# Altium schematic sheet FPGA.SchDoc(FPGA)
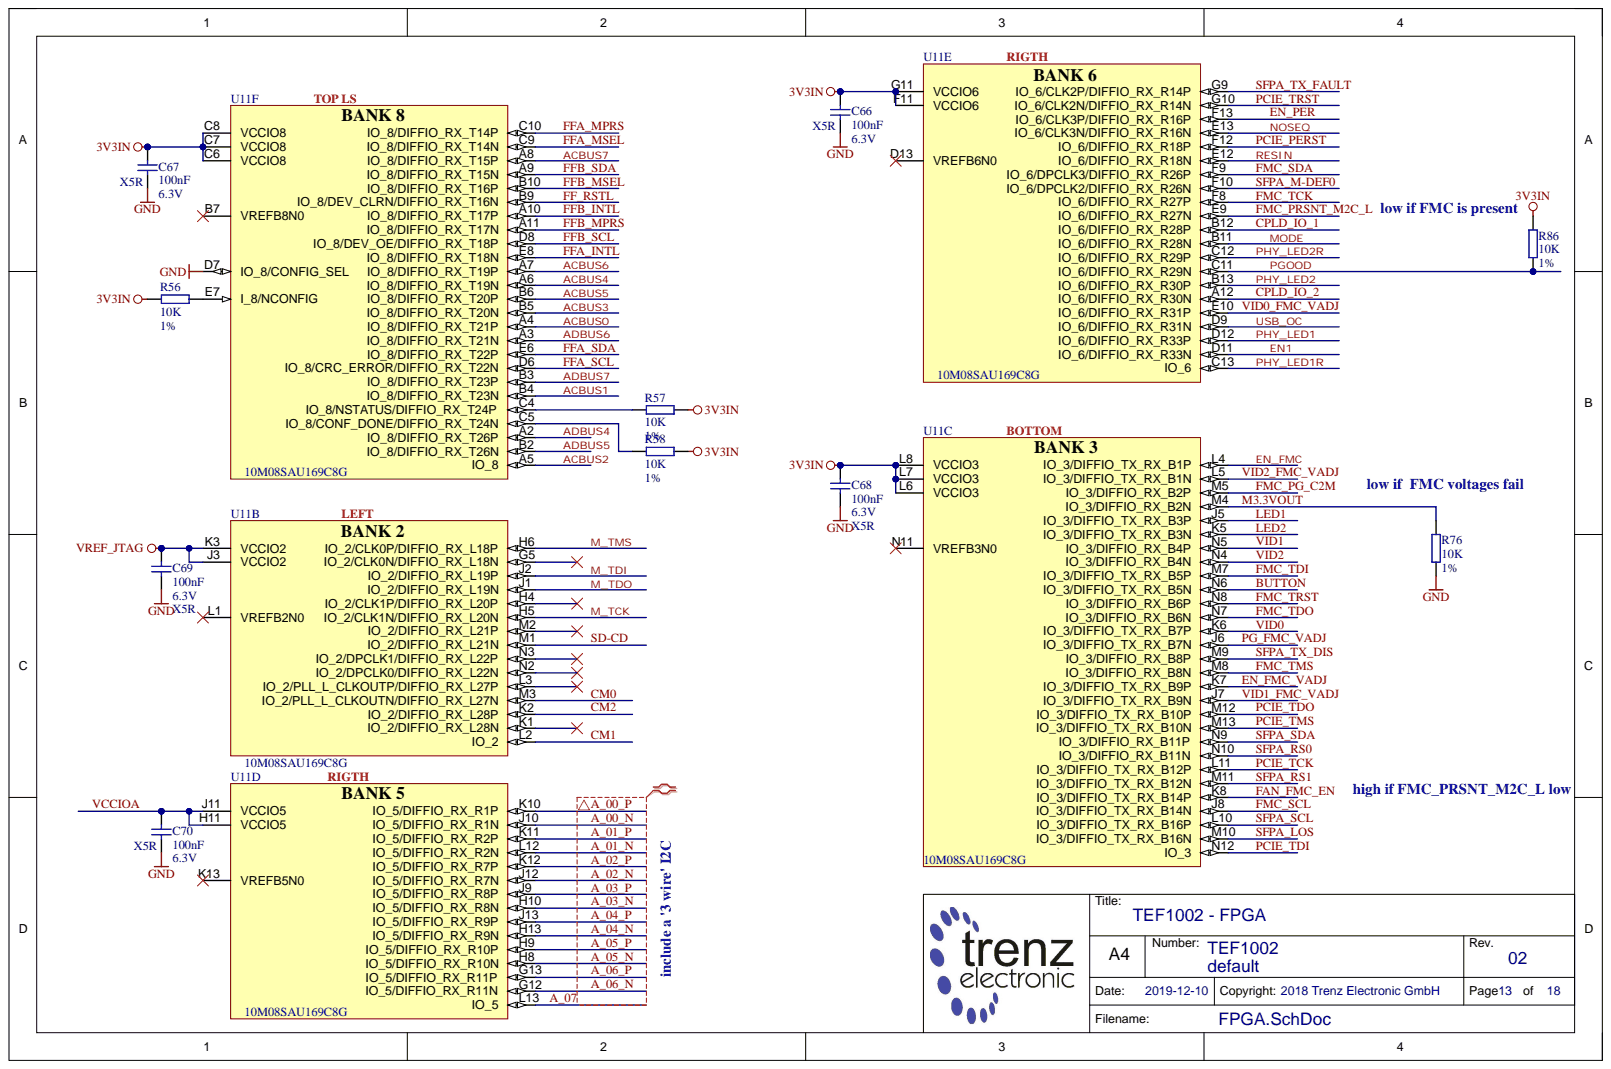
[diagram p0: FPGA.SchDoc]

## Parts
C66  100nF  Technology: X5R  Voltage: 6.3V  [CAPC0603X33N]
C67  100nF  Technology: X5R  Voltage: 6.3V  [CAPC0603X33N]
C68  100nF  Technology: X5R  Voltage: 6.3V  [CAPC0603X33N]
C69  100nF  Voltage: 6.3V  [CAPC0603X33N]
C70  100nF  Technology: X5R  Voltage: 6.3V  [CAPC0603X33N]
R56  10K  Tolerance: 1%  [RESC1005X40N]
R57  10K  [RESC1005X40N]
R58  10K  Tolerance: 1%  [RESC1005X40N]
R76  10K  Tolerance: 1%  [RESC1005X40N]
R86  10K  Tolerance: 1%  [RESC1005X40N]
U11  10M08SAU169C8G  [BGA169C80P13X13_11X11X15]  (parts B,C,D,E,F)

## Nets
3V3IN: C66.1 C67.1 C68.1 R56.1 R57.1 R58.1 R86.1 U11.C6(VCCIO8) U11.C7(VCCIO8) U11.C8(VCCIO8) U11.F11(VCCIO6) U11.G11(VCCIO6) U11.L6(VCCIO3) U11.L7(VCCIO3) U11.L8(VCCIO3)
GND: C66.2 C67.2 C68.2 C69.2 C70.2 R76.1 U11.D7(IO_8/CONFIG_SEL)
VREF_JTAG: C69.1 U11.J3(VCCIO2) U11.K3(VCCIO2)
A_00_N: U11.J10(IO_5/DIFFIO_RX_R1N)
A_00_P: U11.K10(IO_5/DIFFIO_RX_R1P)
A_01_N: U11.L12(IO_5/DIFFIO_RX_R2N)
A_01_P: U11.K11(IO_5/DIFFIO_RX_R2P)
A_02_N: U11.J12(IO_5/DIFFIO_RX_R7N)
A_02_P: U11.K12(IO_5/DIFFIO_RX_R7P)
A_03_N: U11.H10(IO_5/DIFFIO_RX_R8N)
A_03_P: U11.J9(IO_5/DIFFIO_RX_R8P)
A_04_N: U11.H13(IO_5/DIFFIO_RX_R9N)
A_04_P: U11.J13(IO_5/DIFFIO_RX_R9P)
A_05_N: U11.H8(IO_5/DIFFIO_RX_R10N)
A_05_P: U11.H9(IO_5/DIFFIO_RX_R10P)
A_06_N: U11.G12(IO_5/DIFFIO_RX_R11N)
A_06_P: U11.G13(IO_5/DIFFIO_RX_R11P)
A_07: U11.L13(IO_5)
ACBUS0: U11.A4(IO_8/DIFFIO_RX_T21P)
ACBUS1: U11.B4(IO_8/DIFFIO_RX_T23N)
ACBUS2: U11.A5(IO_8)
ACBUS3: U11.B5(IO_8/DIFFIO_RX_T20N)
ACBUS4: U11.A6(IO_8/DIFFIO_RX_T19N)
ACBUS5: U11.B6(IO_8/DIFFIO_RX_T20P)
ACBUS6: U11.A7(IO_8/DIFFIO_RX_T19P)
ACBUS7: U11.A8(IO_8/DIFFIO_RX_T15P)
ADBUS4: U11.A2(IO_8/DIFFIO_RX_T26P)
ADBUS5: U11.B2(IO_8/DIFFIO_RX_T26N)
ADBUS6: U11.A3(IO_8/DIFFIO_RX_T21N)
ADBUS7: U11.B3(IO_8/DIFFIO_RX_T23P)
BUTTON: U11.N6(IO_3/DIFFIO_TX_RX_B5N)
CM0: U11.M3(IO_2/PLL_L_CLKOUTN/DIFFIO_RX_L27N)
CM1: U11.L2(IO_2)
CM2: U11.K2(IO_2/DIFFIO_RX_L28P)
CPLD_IO_1: U11.B12(IO_6/DIFFIO_RX_R28P)
CPLD_IO_2: U11.A12(IO_6/DIFFIO_RX_R30N)
EN1: U11.D11(IO_6/DIFFIO_RX_R33N)
EN_FMC: U11.L4(IO_3/DIFFIO_TX_RX_B1P)
EN_FMC_VADJ: U11.K7(IO_3/DIFFIO_TX_RX_B9P)
EN_PER: U11.F13(IO_6/CLK3P/DIFFIO_RX_R16P)
FAN_FMC_EN: U11.K8(IO_3/DIFFIO_TX_RX_B14P)
FF_RSTL: U11.B9(IO_8/DEV_CLRN/DIFFIO_RX_T16N)
FFA_INTL: U11.E8(IO_8/DIFFIO_RX_T18N)
FFA_MPRS: U11.C10(IO_8/DIFFIO_RX_T14P)
FFA_MSEL: U11.C9(IO_8/DIFFIO_RX_T14N)
FFA_SCL: U11.D6(IO_8/CRC_ERROR/DIFFIO_RX_T22N)
FFA_SDA: U11.E6(IO_8/DIFFIO_RX_T22P)
FFB_INTL: U11.A10(IO_8/DIFFIO_RX_T17P)
FFB_MPRS: U11.A11(IO_8/DIFFIO_RX_T17N)
FFB_MSEL: U11.B10(IO_8/DIFFIO_RX_T16P)
FFB_SCL: U11.D8(IO_8/DEV_OE/DIFFIO_RX_T18P)
FFB_SDA: U11.A9(IO_8/DIFFIO_RX_T15N)
FMC_PG_C2M: U11.M5(IO_3/DIFFIO_RX_B2P)
FMC_PRSNT_M2C_L: U11.E9(IO_6/DIFFIO_RX_R27N)
FMC_SCL: U11.J8(IO_3/DIFFIO_TX_RX_B14N)
FMC_SDA: U11.F9(IO_6/DPCLK3/DIFFIO_RX_R26P)
FMC_TCK: U11.F8(IO_6/DIFFIO_RX_R27P)
FMC_TDI: U11.M7(IO_3/DIFFIO_TX_RX_B5P)
FMC_TDO: U11.N7(IO_3/DIFFIO_RX_B6N)
FMC_TMS: U11.M8(IO_3/DIFFIO_RX_B8N)
FMC_TRST: U11.N8(IO_3/DIFFIO_RX_B6P)
LED1: U11.J5(IO_3/DIFFIO_TX_RX_B3P)
LED2: U11.K5(IO_3/DIFFIO_TX_RX_B3N)
M3.3VOUT: R76.2 U11.M4(IO_3/DIFFIO_RX_B2N)
M_TCK: U11.H5(IO_2/CLK1N/DIFFIO_RX_L20N)
M_TDI: U11.J2(IO_2/DIFFIO_RX_L19P)
M_TDO: U11.J1(IO_2/DIFFIO_RX_L19N)
M_TMS: U11.H6(IO_2/CLK0P/DIFFIO_RX_L18P)
MODE: U11.B11(IO_6/DIFFIO_RX_R28N)
NOSEQ: U11.E13(IO_6/CLK3N/DIFFIO_RX_R16N)
PCIE_PERST: U11.F12(IO_6/DIFFIO_RX_R18P)
PCIE_TCK: U11.L11(IO_3/DIFFIO_TX_RX_B12P)
PCIE_TDI: U11.N12(IO_3)
PCIE_TDO: U11.M12(IO_3/DIFFIO_TX_RX_B10P)
PCIE_TMS: U11.M13(IO_3/DIFFIO_TX_RX_B10N)
PCIE_TRST: U11.G10(IO_6/CLK2N/DIFFIO_RX_R14N)
PG_FMC_VADJ: U11.J6(IO_3/DIFFIO_TX_RX_B7N)
PGOOD: R86.2 U11.C11(IO_6/DIFFIO_RX_R29N)
PHY_LED1: U11.D12(IO_6/DIFFIO_RX_R33P)
PHY_LED1R: U11.C13(IO_6)
PHY_LED2: U11.B13(IO_6/DIFFIO_RX_R30P)
PHY_LED2R: U11.C12(IO_6/DIFFIO_RX_R29P)
RESIN: U11.E12(IO_6/DIFFIO_RX_R18N)
SD-CD: U11.M1(IO_2/DIFFIO_RX_L21N)
SFPA_LOS: U11.M10(IO_3/DIFFIO_TX_RX_B16N)
SFPA_M-DEF0: U11.F10(IO_6/DPCLK2/DIFFIO_RX_R26N)
SFPA_RS0: U11.N10(IO_3/DIFFIO_RX_B11N)
SFPA_RS1: U11.M11(IO_3/DIFFIO_TX_RX_B12N)
SFPA_SCL: U11.L10(IO_3/DIFFIO_TX_RX_B16P)
SFPA_SDA: U11.N9(IO_3/DIFFIO_RX_B11P)
SFPA_TX_DIS: U11.M9(IO_3/DIFFIO_RX_B8P)
SFPA_TX_FAULT: U11.G9(IO_6/CLK2P/DIFFIO_RX_R14P)
USB_OC: U11.D9(IO_6/DIFFIO_RX_R31N)
VCCIOA: C70.1 U11.H11(VCCIO5) U11.J11(VCCIO5)
VID0: U11.K6(IO_3/DIFFIO_TX_RX_B7P)
VID0_FMC_VADJ: U11.E10(IO_6/DIFFIO_RX_R31P)
VID1: U11.N5(IO_3/DIFFIO_RX_B4P)
VID1_FMC_VADJ: U11.J7(IO_3/DIFFIO_TX_RX_B9N)
VID2: U11.N4(IO_3/DIFFIO_RX_B4N)
VID2_FMC_VADJ: U11.L5(IO_3/DIFFIO_TX_RX_B1N)
NetR56_2: R56.2 U11.E7(I_8/NCONFIG)
NetR57_2: R57.2 U11.C4(IO_8/NSTATUS/DIFFIO_RX_T24P)
NetR58_2: R58.2 U11.C5(IO_8/CONF_DONE/DIFFIO_RX_T24N)

Not connected: U11 B7(VREFB8N0) D13(VREFB6N0) G5(IO_2/CLK0N/DIFFIO_RX_L18N) H4(IO_2/CLK1P/DIFFIO_RX_L20P) K1(IO_2/DIFFIO_RX_L28N) K13(VREFB5N0) L1(VREFB2N0) L3(IO_2/PLL_L_CLKOUTP/DIFFIO_RX_L27P) M2(IO_2/DIFFIO_RX_L21P) N2(IO_2/DPCLK0/DIFFIO_RX_L22N) N3(IO_2/DPCLK1/DIFFIO_RX_L22P) N11(VREFB3N0)

## Library data of the parts
C66-C70 = 24915: KON 100nF 6.3V X5R 10% SMD BF 0603 (0201); Database Table Name: C_0603_0201; Mixed: SMT; Preferred: Yes; Temperature-Range: -55/+85; Value: 100n; AN: 24915; Case: 0603 (0201); Component Kind: Standard; Library Name: TE.DbLib; Tolerance: 10%
  C69: Technology: X5R
R56-R58 R76 R86 = 22750: WID SMD 10K 1% 0,063W BF 1005 (0402); Database Table Name: R_1005_0402; H-ART: RC0402FR-0710KL; Mixed: SMT; Preferred: Yes; AN: 22750; Case: 1005 (0402); Component Kind: Standard; Library Name: TE.DbLib; Technology: TK100; Value: 10K
  R57: Tolerance: 1%
U11 = 27930: Database Table Name: PROG_LOGIC; Mixed: SMT; Temperature-Range: 0/+85 (Tj); AN: 27930; Case: LFBGA169; Component Kind: Standard; Description: 10M08SAU169C8G; H-ART: 10M08SAU169C8G; Library Name: TE.DbLib; Storage-Temperature: -65/+150(Abs); HelpURL: b:\AD\adlib\datasheet\ALTERA_MAX10\
